# Altium schematic sheet Comms.SchDoc
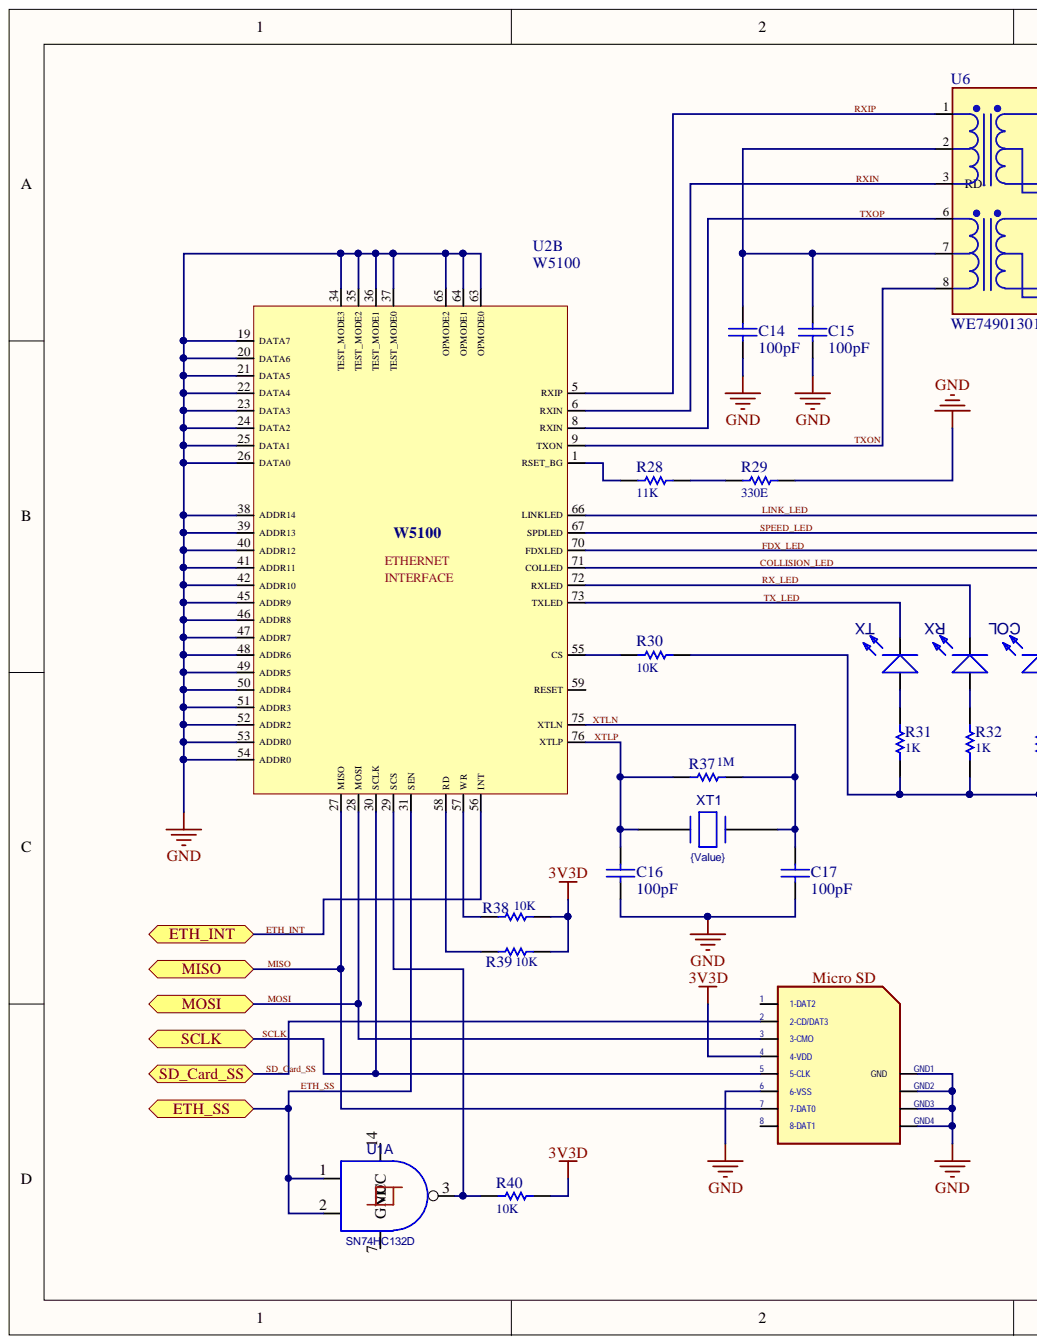
[diagram p0: Comms.SchDoc, left]
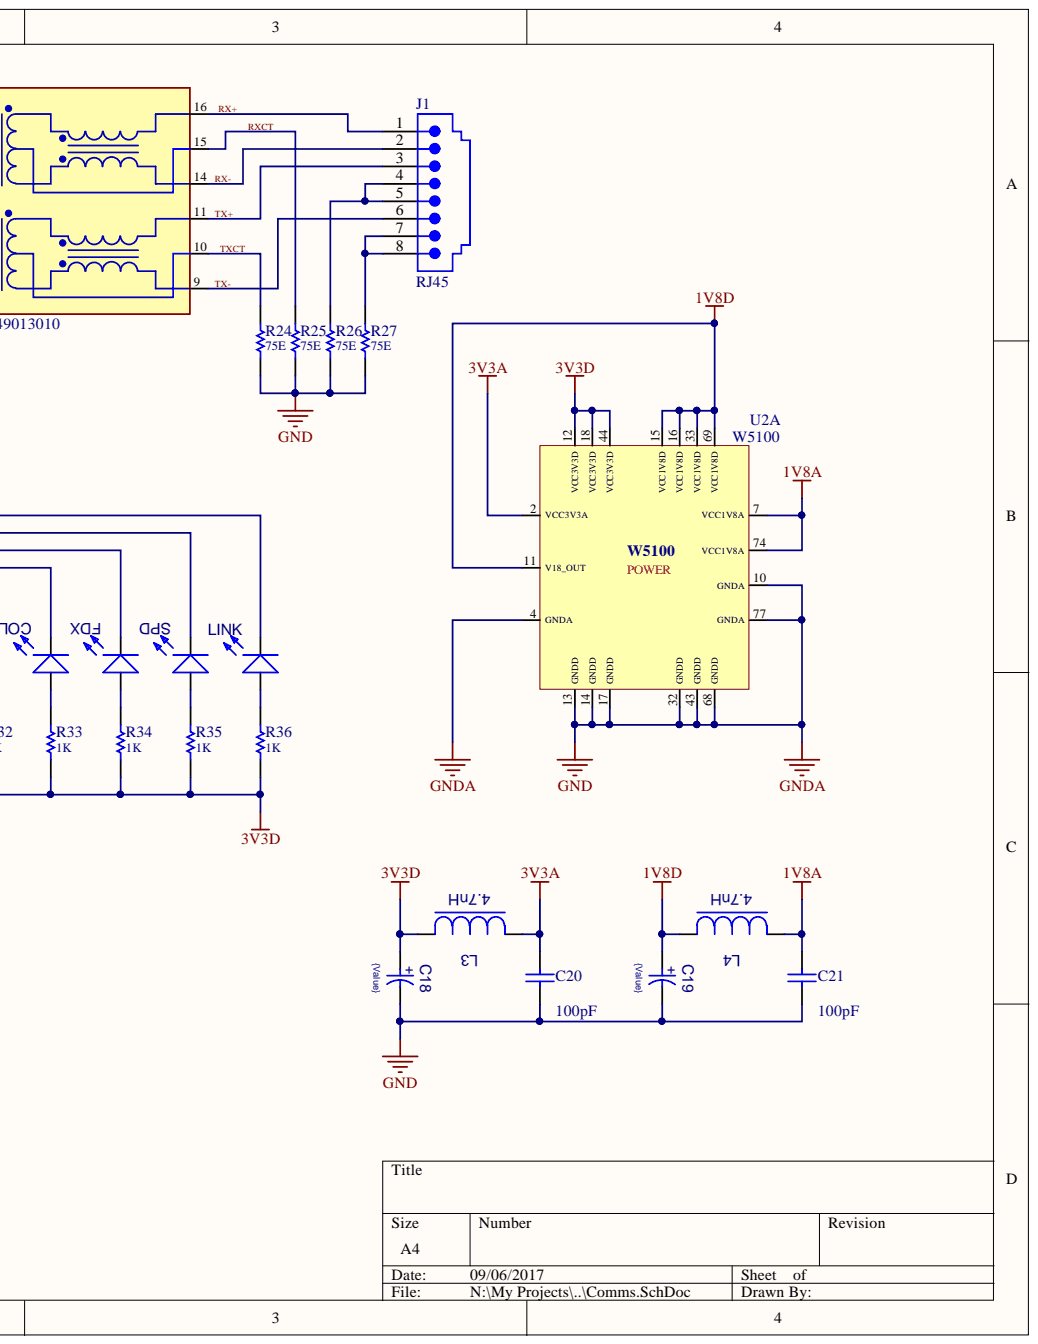
[diagram p1: Comms.SchDoc, right]

## Parts
C14  value 100pF  [C-0805]  (hidden comment: Cap 0805)
C15  value 100pF  [C-0805]  (hidden comment: Cap 0805)
C16  value 100pF  [C-0805]  (hidden comment: Cap 0805)
C17  value 100pF  [C-0805]  (hidden comment: Cap 0805)
C18  value {Value}  [C-TANT#A]  (hidden comment: Cap Tantalum TH)
C19  value {Value}  [C-TANT#A]  (hidden comment: Cap Tantalum TH)
C20  value 100pF  [C-0805]  (hidden comment: Cap 0805)
C21  value 100pF  [C-0805]  (hidden comment: Cap 0805)
COL  LED-SMD_0805-RED  [LED3mm-Through Hole]  (value not drawn)
FDX  LED-SMD_0805-GREEN  [LED-0805 - Red]  (value not drawn)
J1  RJ45  [RJ45 - VERT]
L3  value 4.7nH  [L-0805]  (hidden comment: Ferrire Bead-SMT 0805)
L4  value 4.7nH  [L-0805]  (hidden comment: Ferrire Bead-SMT 0805)
LINK  LED-SMD_0805-GREEN  [LED-0805 - Red]  (value not drawn)
Micro_SD  SDCARD  [SDCARD-15TW-8821]  (value not drawn)
R24  value 75E  [R-0805 - 75E]  (hidden comment: Resistor - SMD 0805)
R25  value 75E  [R-0805 - 75E]  (hidden comment: Resistor - SMD 0805)
R26  value 75E  [R-0805 - 75E]  (hidden comment: Resistor - SMD 0805)
R27  value 75E  [R-0805 - 75E]  (hidden comment: Resistor - SMD 0805)
R28  value 11K  [R-0805 - 12K]  (hidden comment: Resistor - SMD 0805)
R29  value 330E  [R-0805 - 330E]  (hidden comment: Resistor - SMD 0805)
R30  value 10K  [R-0805 - 10K]  (hidden comment: Resistor - SMD 0805)
R31  value 1K  [R-0805 - 1K]  (hidden comment: Resistor - SMD 0805)
R32  value 1K  [R-0805 - 1K]  (hidden comment: Resistor - SMD 0805)
R33  value 1K  [R-0805 - 1K]  (hidden comment: Resistor - SMD 0805)
R34  value 1K  [R-0805 - 1K]  (hidden comment: Resistor - SMD 0805)
R35  value 1K  [R-0805 - 1K]  (hidden comment: Resistor - SMD 0805)
R36  value 1K  [R-0805 - 1K]  (hidden comment: Resistor - SMD 0805)
R37  value 1M  [R-0805 - 1M]  (hidden comment: Resistor - SMD 0805)
R38  value 10K  [R-0805 - 10K]  (hidden comment: Resistor - SMD 0805)
R39  value 10K  [R-0805 - 10K]  (hidden comment: Resistor - SMD 0805)
R40  value 10K  [R-0805 - 10K]  (hidden comment: Resistor - SMD 0805)
RX  LED-SMD_0805-GREEN  [LED-0805 - Red]  (value not drawn)
SPD  LED-SMD_0805-GREEN  [LED-0805 - Red]  (value not drawn)
TX  LED-SMD_0805-GREEN  [LED-0805 - Red]  (value not drawn)
U1  SN74HC132D  [SN74HC132D]  (part A)
U2  W5100  [W5100]  (parts A,B)
U6  WE749013010  [WE 749013010]
XT1  value {Value}  [XTAL - HC49S 25MHz]  (hidden comment: CRYSTAL-25MHz - Low Profile)

## Ports
ETH_INT, ETH_SS, MISO, MOSI, SCLK, SD_Card_SS

## Nets
1V8A: C21.2 L4.1 U2.7(VCC1V8A) U2.74(VCC1V8A)
1V8D: C19.PLUS L4.2 U2.11(V18_OUT) U2.15(VCC1V8D) U2.16(VCC1V8D) U2.33(VCC1V8D) U2.69(VCC1V8D)
3V3A: C20.2 L3.1 U2.2(VCC3V3A)
3V3D: C18.PLUS L3.2 Micro_SD.4(4-VDD) R30.2 R31.1 R32.1 R33.1 R34.1 R35.1 R36.1 R38.2 R39.2 R40.2 U2.12(VCC3V3D) U2.18(VCC3V3D) U2.44(VCC3V3D)
GND: C14.1 C15.1 C16.1 C17.1 C18.MINUS C19.MINUS C20.1 C21.1 Micro_SD.6(6-VSS) Micro_SD.GND1(GND) Micro_SD.GND2 Micro_SD.GND3 Micro_SD.GND4 R24.1 R25.1 R26.1 R27.1 R29.2 U2.4(GNDA) U2.10(GNDA) U2.13(GNDD) U2.14(GNDD) U2.17(GNDD) U2.19(DATA7) U2.20(DATA6) U2.21(DATA5) U2.22(DATA4) U2.23(DATA3) U2.24(DATA2) U2.25(DATA1) U2.26(DATA0) U2.32(GNDD) U2.34(TEST_MODE3) U2.35(TEST_MODE2) U2.36(TEST_MODE1) U2.37(TEST_MODE0) U2.38(ADDR14) U2.39(ADDR13) U2.40(ADDR12) U2.41(ADDR11) U2.42(ADDR10) U2.43(GNDD) U2.45(ADDR9) U2.46(ADDR8) U2.47(ADDR7) U2.48(ADDR6) U2.49(ADDR5) U2.50(ADDR4) U2.51(ADDR3) U2.52(ADDR2) U2.53(ADDR0) U2.54(ADDR0) U2.63(OPMODE0) U2.64(OPMODE1) U2.65(OPMODE2) U2.68(GNDD) U2.77(GNDA)
COLLISION_LED: COL.1 U2.71(COLLED)
ETH_INT: U2.56(INT) ; port ETH_INT
ETH_SS: U1.1 U1.2 U2.31(SEN) ; port ETH_SS
FDX_LED: FDX.1 U2.70(FDXLED)
LINK_LED: LINK.1 U2.66(LINKLED)
MISO: Micro_SD.7(7-DAT0) U2.27(MISO) ; port MISO
MOSI: Micro_SD.3(3-CMO) U2.28(MOSI) ; port MOSI
RX+: J1.1 U6.16
RX-: J1.2 U6.14
RX_LED: RX.1 U2.72(RXLED)
RXCT: R25.2 U6.15
RXIN: U2.6(RXIN) U6.3(RD-)
RXIP: U2.5(RXIP) U6.1
SCLK: Micro_SD.5(5-CLK) U2.30(SCLK) ; port SCLK
SD_Card_SS: Micro_SD.2(2-CD/DAT3) ; port SD_Card_SS
SPEED_LED: SPD.1 U2.67(SPDLED)
TX+: J1.3 U6.11
TX-: J1.6 U6.9
TX_LED: TX.1 U2.73(TXLED)
TXCT: R24.2 U6.10
TXON: U2.9(TXON) U6.8
TXOP: U2.8(RXIN) U6.6
XTLN: C17.2 R37.2 U2.75(XTLN) XT1.2
XTLP: C16.2 R37.1 U2.76(XTLP) XT1.1
NetC14_2: C14.2 C15.2 U6.2 U6.7
NetCOL_2: COL.2 R33.2
NetFDX_2: FDX.2 R34.2
NetJ1_4: J1.4 J1.5 R26.2
NetJ1_7: J1.7 J1.8 R27.2
NetLINK_2: LINK.2 R36.2
NetR28_1: R28.1 U2.1(RSET_BG)
NetR28_2: R28.2 R29.1
NetR30_1: R30.1 U2.55(CS)
NetR31_2: R31.2 TX.2
NetR32_2: R32.2 RX.2
NetR35_2: R35.2 SPD.2
NetR38_1: R38.1 U2.57(WR)
NetR39_1: R39.1 U2.58(RD)
NetR40_1: R40.1 U1.3 U2.29(SCS)

Not connected: Micro_SD 1(1-DAT2) 8(8-DAT1); U1 7(GND) 14(VCC); U2 59(RESET)

## Library data of the parts
C14-C17 C20 C21 = Cap 0805: Capacitor SMD; Component Kind: Standard; LatestRevisionDate: 17-Jul-2002; LatestRevisionNote: Re-released for DXP Platform.; PackageReference: C1206; Published: 8-Jun-2000; Library Name: MKM Capacitors.IntLib; Publisher: Altium Limited
C18 C19 = C-TANT#A: Tantalum Capacitor SMD; Component Kind: Standard; Library Name: MKM Capacitors.IntLib
COL = LED-SMD_0805-RED: LED 0805 Red; Assy: Loaded; CH: WL-08-2004; CR: VV; Vendor: Fairchild; Vendor P/N: QTLP610C-AG; ComponentHeight: 1.10mm; Component Kind: Standard; Library Name: MKM Optoelectronics.IntLib
FDX LINK RX SPD TX = LED-SMD_0805-GREEN: LED SMD 0805-GREEN; Assy: Loaded; CH: WL-08-2004; CR: VV; Vendor: Fairchild; Vendor P/N: QTLP610C-AG; ComponentHeight: 1.10mm; Component Kind: Standard; Library Name: MKM Optoelectronics.IntLib
J1 = RJ45: Right Angle, Standard Profile, RJ45-Keyed, Flange Mount PCB Jack; ComponentAvailability: Obsolete; DrillSize: Nominal, Rounded to nearest 0.05mm; PCB_Layout: Complies with Manufacturer's Recommendation.; PCB_Mounting: Thru-Hole; PerformanceCategory: 3; Ports: 1; Positions/Loaded: Port1 - 8 Keyed/8; Component Kind: Standard; ComponentLink1Description: Manufacturer Link; ComponentLink1URL: http://www.molex.com/; ComponentLink2Description: Datasheet; ComponentLink2URL: http://www.molex.com/cmc_upload/common_files/1212003_catalogmx41314.pdf; ComponentLink3Description: Iges Model; ComponentLink3URL: http://www.molex.com/pdm_docs/igs/15-43-6233_igs.zip; DatasheetDocument: 18-Dec-2001; PackageDocument: 18-Dec-2001; PackageReference: 15-43-6233; Published: 25-Jun-2002; Library Name: MKM Connectors.IntLib; Publisher: Altium Limited
L3 L4 = Ferrire Bead-SMT 0805: Ferrire Bead -SMT 0805; Component Kind: Standard; Library Name: MKM Inductance_transformers.IntLib
Micro_SD = SDCARD: SD Card SDCARD 15TW 8821; Component Kind: Standard; Library Name: MKM Connectors.IntLib
R24-R27 = R0805- 75E: Component Kind: Standard; Description: Resistor - SMD 0805; LatestRevisionDate: 17-Jul-2002; LatestRevisionNote: Re-released for DXP Platform.; PackageReference: AXIAL-0.3; Published: 8-Jun-2000; Signal Integrity: Res; Simulation: RESISTOR; Library Name: MKM Resistors.IntLib; Publisher: Altium Limited
R28 = R0805- 12K_1%: Component Kind: Standard; Description: Resistor - SMD 0805; LatestRevisionDate: 17-Jul-2002; LatestRevisionNote: Re-released for DXP Platform.; PackageReference: AXIAL-0.3; Published: 8-Jun-2000; Signal Integrity: Res; Simulation: RESISTOR; Library Name: MKM Resistors.IntLib; Publisher: Altium Limited
R29 = R0805- 330E: Component Kind: Standard; Description: Resistor - SMD 0805; LatestRevisionDate: 17-Jul-2002; LatestRevisionNote: Re-released for DXP Platform.; PackageReference: AXIAL-0.3; Published: 8-Jun-2000; Signal Integrity: Res; Simulation: RESISTOR; Library Name: MKM Resistors.IntLib; Publisher: Altium Limited
R30 R38-R40 = R0805- 10K: Component Kind: Standard; Description: Resistor - SMD 0805; LatestRevisionDate: 17-Jul-2002; LatestRevisionNote: Re-released for DXP Platform.; PackageReference: AXIAL-0.3; Published: 8-Jun-2000; Signal Integrity: Res; Simulation: RESISTOR; Library Name: MKM Resistors.IntLib; Publisher: Altium Limited
R31-R36 = R0805- 1K: Component Kind: Standard; Description: Resistor - SMD 0805; LatestRevisionDate: 17-Jul-2002; LatestRevisionNote: Re-released for DXP Platform.; PackageReference: AXIAL-0.3; Published: 8-Jun-2000; Signal Integrity: Res; Simulation: RESISTOR; Library Name: MKM Resistors.IntLib; Publisher: Altium Limited
R37 = R0805- 1M: Component Kind: Standard; Description: Resistor - SMD 0805; LatestRevisionDate: 17-Jul-2002; LatestRevisionNote: Re-released for DXP Platform.; PackageReference: AXIAL-0.3; Published: 8-Jun-2000; Signal Integrity: Res; Simulation: RESISTOR; Library Name: MKM Resistors.IntLib; Publisher: Altium Limited
U1 = SN74HC132D: QUAD 2-Input NAND Schmitt Trigger; Assy: Loaded; CH: WL-07-2004; CR: WL; Vendor: Texas Instruments; ComponentHeight: 1.75mm; Component Kind: Standard; VendorP/N: SN74HC132; Library Name: MKM ICs.IntLib
U6 = WE749013010: Ethernet Magnetic IC; Component Kind: Standard; LatestRevisionDate: 17-Jul-2002; LatestRevisionNote: Re-released for DXP Platform.; PackageDescription: Transformer; 5 Leads; PackageReference: TRF_5; Published: 8-Jun-2000; Library Name: MKM ICs.IntLib; Publisher: MKM Technologies
XT1 = CRYSTAL-25MHz - Low Profile: Crystal Vert Metal Can (11x5mm); CH: NN-02-2002; CR: NN; Component Kind: Standard; Library Name: MKM Miscellenious devices.IntLib
U2 = W5100: Component Kind: Standard; Library Name: MKM Tech ICs.IntLib
